# Altium schematic sheet XIAO-HAT.SchDoc
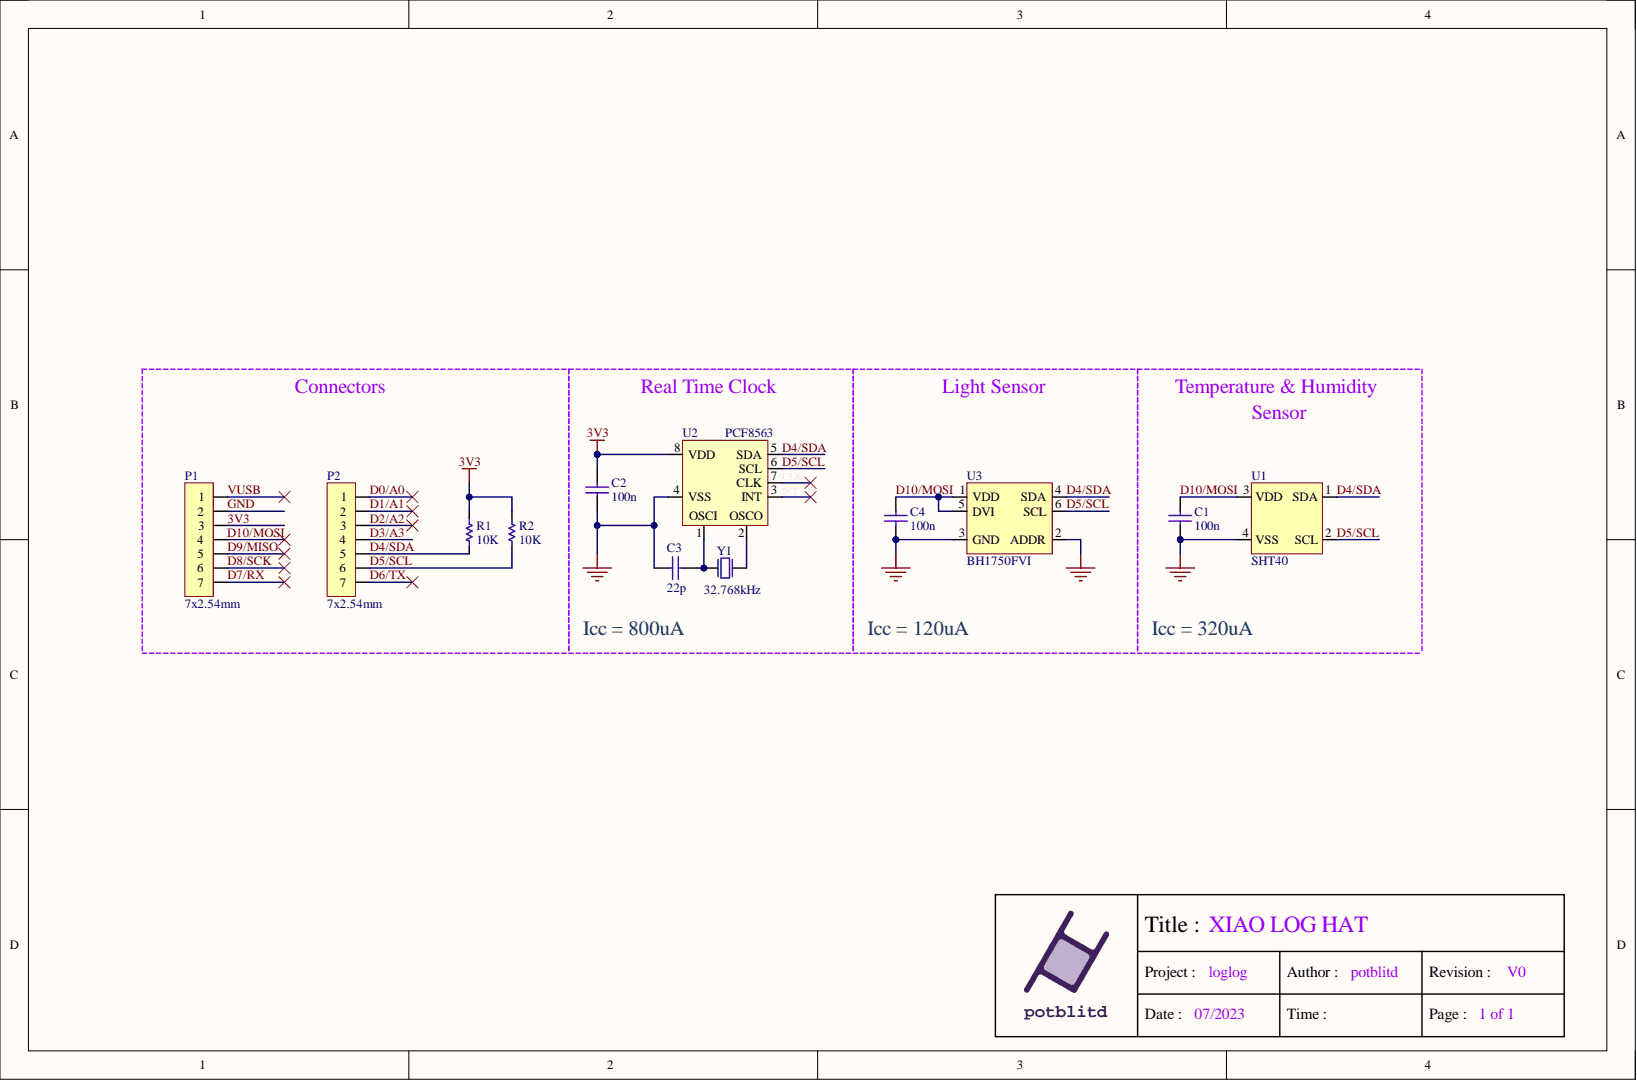
[diagram p0: XIAO-HAT.SchDoc]

## Parts
C1  value 100n  [0603C]  (hidden comment: Capacitor)
C2  value 100n  [0603C]  (hidden comment: Capacitor)
C3  value 22p  [0603C]  (hidden comment: Capacitor)
C4  value 100n  [0603C]  (hidden comment: Capacitor)
P1  7x2.54mm  [7P-2.54mm-0.84]
P2  7x2.54mm  [7P-2.54mm-0.84]
R1  value 10K  [0603R]  (hidden comment: Resistor)
R2  value 10K  [0603R]  (hidden comment: Resistor)
U1  SHT40  [DFN-4-EP(1.5x1.5)]
U2  PCF8563  [SOP-8]
U3  BH1750FVI  [WSOF6]
Y1  value 32.768kHz  [FC135]  (hidden comment: Oscillator)

## Nets
3V3: C2.1 P1.3 R1.2 R2.2 U2.8(VDD)
CLK: U2.7(CLK)
D0/A0: P2.1
D1/A1: P2.2
D2/A2: P2.3
D3/A3: P2.4
D4/SDA: P2.5 R1.1 U1.1(SDA) U2.5(SDA) U3.4(SDA)
D5/SCL: P2.6 R2.1 U1.2(SCL) U2.6(SCL) U3.6(SCL)
D6/TX: P2.7
D7/RX: P1.7
D8/SCK: P1.6
D9/MISO: P1.5
D10/MOSI: C1.1 C4.1 P1.4 U1.3(VDD) U3.1(VDD) U3.5(DVI)
GND: C1.2 C2.2 C3.2 C4.2 P1.2 U1.4(VSS) U2.4(VSS) U3.2(ADDR) U3.3(GND)
INT: U2.3(INT)
VUSB: P1.1
NetC3_1: C3.1 U2.1 Y1.2
NetU2_2: U2.2 Y1.1

## Library data of the parts
C1 C2 C4 = Capacitor: Capacitor 100nF ±10% 50V; Component: CL05B104KB54PNC; Component Kind: Standard; Library Name: Simulation Generic Components.IntLib; Simulation: C_Capacitor_Default
C3 = Capacitor: Capacitor 22pF ±5% 50V; Component: 0402CG220J500NT; Component Kind: Standard; Library Name: Simulation Generic Components.IntLib; Simulation: C_Capacitor_Default
P1 P2 = 7-pins Header: General 7-pin connection; Function: XIAO CON; Component Kind: Standard; Library Name: AltiumLib.SchLib
R1 = Resistor: Resistor 10kΩ ±1% 1/16W; Component: 0402WGF1002TCE; Function: SDA pull-up; Component Kind: Standard; Library Name: Simulation Generic Components.IntLib; Simulation: R_Resistor_Default
R2 = Resistor: Resistor 10kΩ ±1% 1/16W; Component: 0402WGF1002TCE; Function: SCL pull-up; Component Kind: Standard; Library Name: Simulation Generic Components.IntLib; Simulation: R_Resistor_Default
U1 = SHT40: SHT40 temperature and humidity sensor; Component: SHT40-AD1B-R2; Function: T&H sensor; Component Kind: Standard; Library Name: AltiumLib.SchLib
U2 = RTC-8563: IC real-time clock with alarm interrupt; Component: PCF8563T/5,518; Function: RTC; Component Kind: Standard; Library Name: AltiumLib.SchLib
U3 = BH1750: Digital light sensor; Function: lux sensor; Component: BH1750FVI; Component Kind: Standard; Library Name: AltiumLib.SchLib
Y1 = XO-2: Crystal oscillator 32.768kHz 20ppm 12.5pF; Component: Q13FC1350000400; Function: RTC XO; Component Kind: Standard; Library Name: AltiumLib.SchLib
